# Altium schematic sheet 05_fmu_mcu2.SchDoc(05_fmu_mcu2)
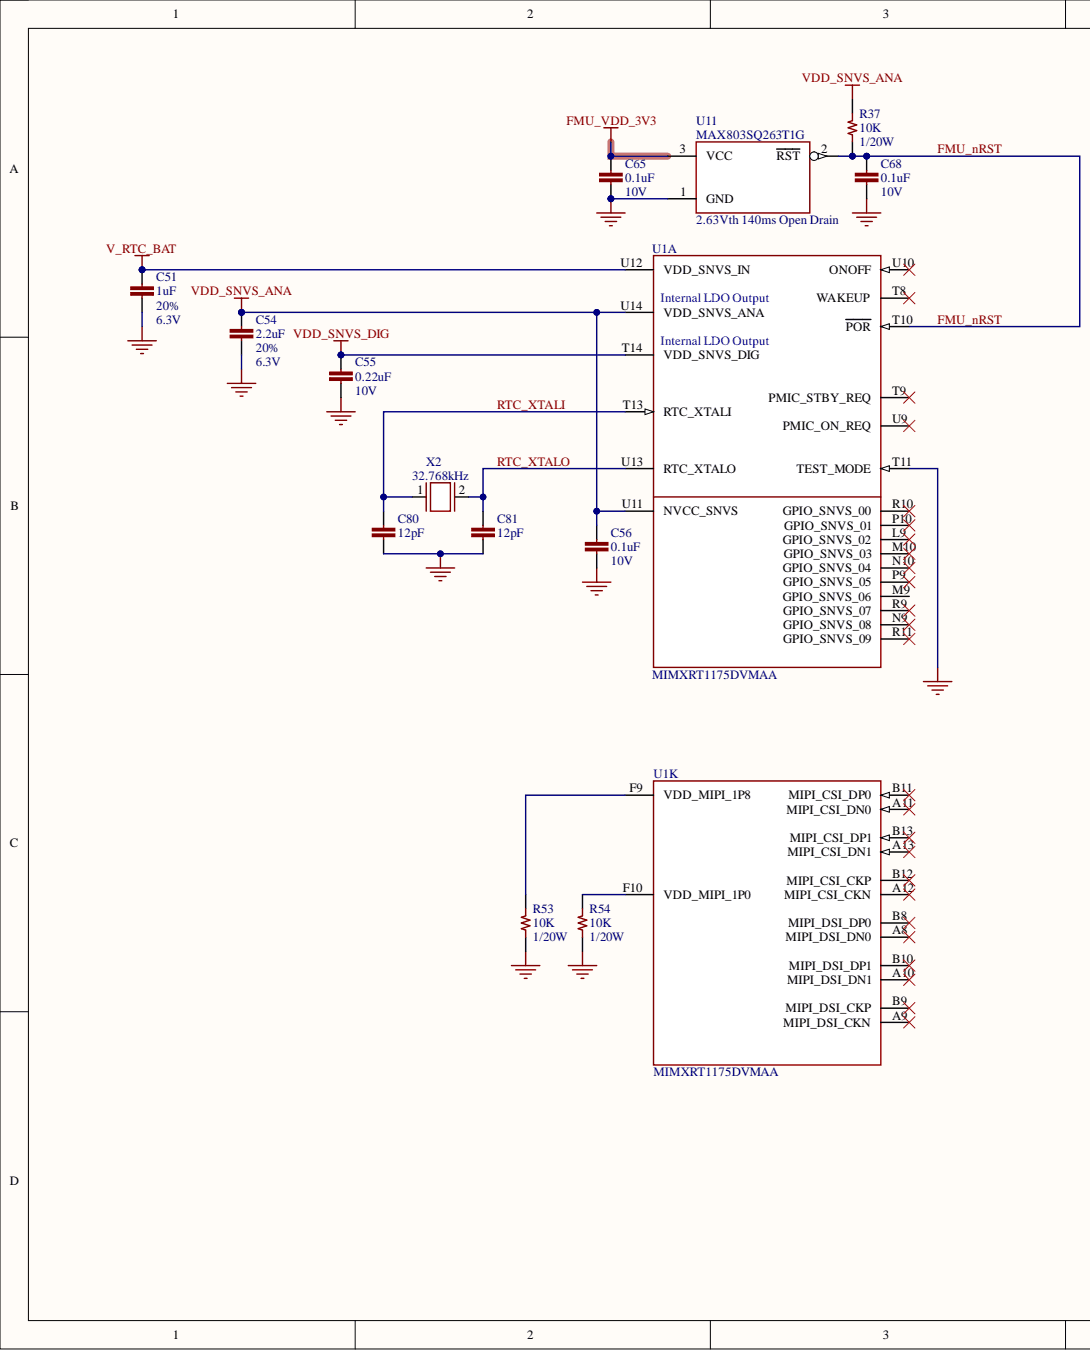
[diagram p0: 05_fmu_mcu2.SchDoc, left]
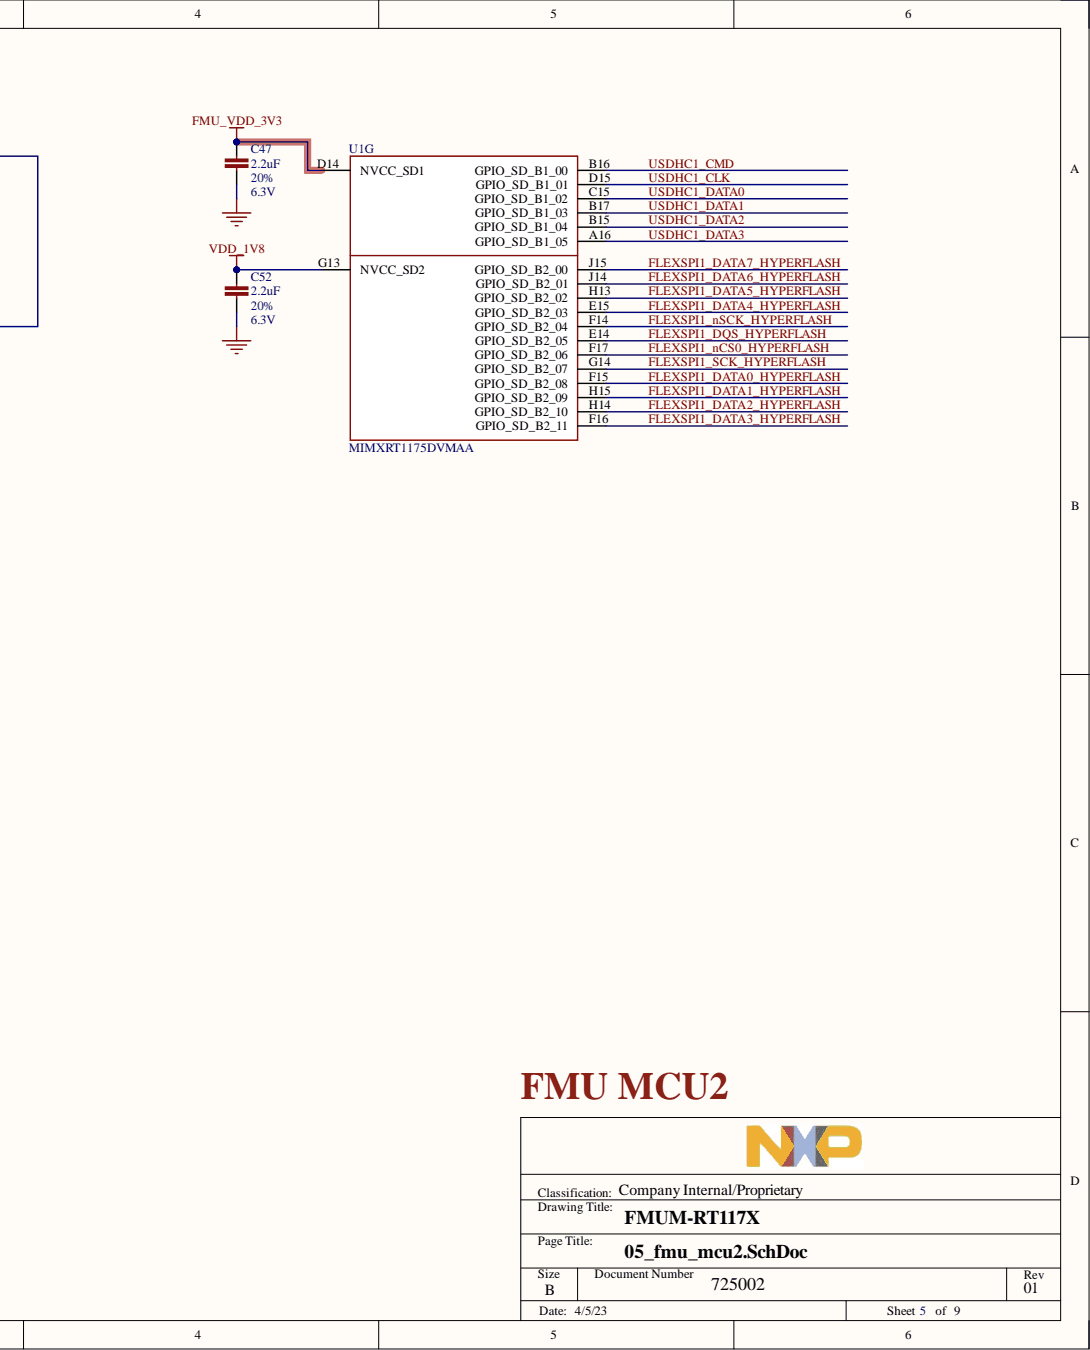
[diagram p1: 05_fmu_mcu2.SchDoc, right]

## Parts
C47  value 2.2uF  Tolerance: 20%  Voltage - Rated: 6.3V  [CAPC60X30X33L15N]  (hidden comment: CAP CER 2.2uF 20% 10V X5R 0201)
C51  value 1uF  Tolerance: 20%  Voltage - Rated: 6.3V  [CAPC60X30X33L15N]  (hidden comment: CAP CER 1uF 20% 10V X5R 0201)
C52  value 2.2uF  Tolerance: 20%  Voltage - Rated: 6.3V  [CAPC60X30X33L15N]  (hidden comment: CAP CER 2.2uF 20% 10V X5R 0201)
C54  value 2.2uF  Tolerance: 20%  Voltage - Rated: 6.3V  [CAPC60X30X33L15N]  (hidden comment: CAP CER 2.2uF 20% 10V X5R 0201)
C55  value 0.22uF  Voltage - Rated: 10V  [CAPC60X30X33L15N]  (hidden comment: CAP CER 0.22uF 10% 10V X5R 0201)
C56  value 0.1uF  Voltage - Rated: 10V  [CAPC60X30X33L15N]  (hidden comment: CAP CER 0.1uF 20% 10V X5R 0201)
C65  value 0.1uF  Voltage - Rated: 10V  [CAPC60X30X33L15N]  (hidden comment: CAP CER 0.1uF 20% 10V X5R 0201)
C68  value 0.1uF  Voltage - Rated: 10V  [CAPC60X30X33L15N]  (hidden comment: CAP CER 0.1uF 20% 10V X5R 0201)
C80  value 12pF  [CAPC60X30X33L15N]  (hidden comment: CAP CER 12PF 1% 50V COG/NPO 0201)
C81  value 12pF  [CAPC60X30X33L15N]  (hidden comment: CAP CER 12PF 1% 50V COG/NPO 0201)
R37  value 10K  Power: 1/20W  [RESC60X30X33L15N]  (hidden comment: RES SMD 10K OHM 1% 1/20W 0201)
R53  value 10K  Power: 1/20W  [RESC60X30X33L15N]  (hidden comment: RES SMD 10K OHM 1% 1/20W 0201)
R54  value 10K  Power: 1/20W  [RESC60X30X33L15N]  (hidden comment: RES SMD 10K OHM 1% 1/20W 0201)
U1  Manufacturer Part Number: MIMXRT1175DVMAA  [BGA289CP80_17X17_1400X1400X152B43N]  (hidden comment: IC MCU 32BIT EXT MEM 800MHz 289MAPBGA)  (parts A,G,K)
U11  ID: 2.63Vth 140ms Open Drain  Manufacturer Part Number: MAX803SQ263T1G  [SOT23-3P65_220X100L38X35]  (hidden comment: IC Supervisor Open Drain 1-Channel SC70-3)
X2  Frequency: 32.768kHz  [XTALDFN2_200X120X60L50X100N]  (hidden comment: CRYSTAL 32.7680KHZ 10PPM 12.5PF SMD)

## Nets
FMU_VDD_3V3: C47.1 C65.1 U1.D14(NVCC_SD1) U11.3
GND: C47.2 C51.2 C52.2 C54.2 C55.2 C56.2 C65.2 C68.2 C80.2 C81.2 R53.2 R54.2 U1.T11(TEST_MODE) U11.1
V_RTC_BAT: C51.1 U1.U12(VDD_SNVS_IN)
VDD_1V8: C52.1 U1.G13(NVCC_SD2)
VDD_SNVS_ANA: C54.1 C56.1 R37.1 U1.U11(NVCC_SNVS) U1.U14(VDD_SNVS_ANA)
VDD_SNVS_DIG: C55.1 U1.T14(VDD_SNVS_DIG)
FLEXSPI1_DATA0_HYPERFLASH: U1.F15(GPIO_SD_B2_08)
FLEXSPI1_DATA1_HYPERFLASH: U1.H15(GPIO_SD_B2_09)
FLEXSPI1_DATA2_HYPERFLASH: U1.H14(GPIO_SD_B2_10)
FLEXSPI1_DATA3_HYPERFLASH: U1.F16(GPIO_SD_B2_11)
FLEXSPI1_DATA4_HYPERFLASH: U1.E15(GPIO_SD_B2_03)
FLEXSPI1_DATA5_HYPERFLASH: U1.H13(GPIO_SD_B2_02)
FLEXSPI1_DATA6_HYPERFLASH: U1.J14(GPIO_SD_B2_01)
FLEXSPI1_DATA7_HYPERFLASH: U1.J15(GPIO_SD_B2_00)
FLEXSPI1_DQS_HYPERFLASH: U1.E14(GPIO_SD_B2_05)
FLEXSPI1_nCS0_HYPERFLASH: U1.F17(GPIO_SD_B2_06)
FLEXSPI1_nSCK_HYPERFLASH: U1.F14(GPIO_SD_B2_04)
FLEXSPI1_SCK_HYPERFLASH: U1.G14(GPIO_SD_B2_07)
FMU_nRST: C68.1 R37.2 U1.T10(POR) U11.2
RTC_XTALI: C80.1 U1.T13(RTC_XTALI) X2.1
RTC_XTALO: C81.1 U1.U13(RTC_XTALO) X2.2
USDHC1_CLK: U1.D15(GPIO_SD_B1_01)
USDHC1_CMD: U1.B16(GPIO_SD_B1_00)
USDHC1_DATA0: U1.C15(GPIO_SD_B1_02)
USDHC1_DATA1: U1.B17(GPIO_SD_B1_03)
USDHC1_DATA2: U1.B15(GPIO_SD_B1_04)
USDHC1_DATA3: U1.A16(GPIO_SD_B1_05)
NetR53_1: R53.1 U1.F9(VDD_MIPI_1P8)
NetR54_1: R54.1 U1.F10(VDD_MIPI_1P0)

Not connected: U1 A8(MIPI_DSI_DN0) A9(MIPI_DSI_CKN) A10(MIPI_DSI_DN1) A11(MIPI_CSI_DN0) A12(MIPI_CSI_CKN) A13(MIPI_CSI_DN1) B8(MIPI_DSI_DP0) B9(MIPI_DSI_CKP) B10(MIPI_DSI_DP1) B11(MIPI_CSI_DP0) B12(MIPI_CSI_CKP) B13(MIPI_CSI_DP1) L9(GPIO_SNVS_02) M9(GPIO_SNVS_06) M10(GPIO_SNVS_03) N9(GPIO_SNVS_08) N10(GPIO_SNVS_04) P9(GPIO_SNVS_05) P10(GPIO_SNVS_01) R9(GPIO_SNVS_07) R10(GPIO_SNVS_00) R11(GPIO_SNVS_09) T8(WAKEUP) T9(PMIC_STBY_REQ) U9(PMIC_ON_REQ) U10(ONOFF)

## Library data of the parts
C47 C52 C54 = CAP_2.2uF_6.3V_X5R_0201: Manufacturer: Murata Electronics; Manufacturer Part Number: GRM033R60J225ME47D; Category: Capacitors; Component Kind: Standard; ComponentLink1Description: https://www.digikey.com/en/products/detail/murata-electronics/GRM033R60J225ME47D/5877388; ComponentLink1URL: https://www.digikey.com/en/products/detail/murata-electronics/GRM033R60J225ME47D/5877388; Description: CAP CER 2.2uF 20% 10V X5R 0201; Operating Temperature: -55°C ~ 85°C; Packaging: Cut Tape (CT); Part Status: Active; Pricing 1: 1=0.18 (USD); Stock 1: 9156088; Supplier 1: Digi-Key; Supplier Part Number 1: 490-13225-1-ND; Library Name: NscDG_Integrated_Library.SchLib
C51 = CAP_1uF_6.3V_X5R_0201: Manufacturer: YAGEO; Manufacturer Part Number: CC0201MPX5R5BB105; Category: Capacitors; Component Kind: Standard; ComponentLink1Description: https://www.digikey.com/en/products/detail/yageo/CC0201MPX5R5BB105/11611316; ComponentLink1URL: https://www.digikey.com/en/products/detail/yageo/CC0201MPX5R5BB105/11611316; Description: CAP CER 1uF 20% 10V X5R 0201; Operating Temperature: -55°C ~ 85°C; Packaging: Cut Tape (CT); Part Status: Active; Pricing 1: 1=0.10 (USD); Stock 1: 20-2927; Supplier 1: Digi-Key; Supplier Part Number 1: 13-CC0201MPX5R5BB105CT-ND; Library Name: NscDG_Integrated_Library.SchLib
C55 = CAP_0.22uF_10V_X5R_0201: Manufacturer: Murata Electronics; Manufacturer Part Number: GRM033R61A224KE90D; Category: Capacitors; Component Kind: Standard; ComponentLink1Description: https://www.digikey.com/en/products/detail/murata-electronics/GRM033R61A224KE90D/5027496; ComponentLink1URL: https://www.digikey.com/en/products/detail/murata-electronics/GRM033R61A224KE90D/5027496; Description: CAP CER 0.22uF 10% 10V X5R 0201; Operating Temperature: -55°C ~ 85°C; Packaging: Cut Tape (CT); Part Status: Active; Pricing 1: 1=0.10 (USD); Stock 1: 4690076; Supplier 1: Digi-Key; Supplier Part Number 1: 490-10411-1-ND; Tolerance: 10%; Library Name: NscDG_Integrated_Library.SchLib
C56 C65 C68 = CAP_0.1uF_10V_X5R_0201: Manufacturer: Murata Electronics; Manufacturer Part Number: GRM033R61A104ME15D; Category: Capacitors; Component Kind: Standard; ComponentLink1Description: https://www.digikey.com/en/products/detail/murata-electronics/GRM033R61A104ME15D/2175191; ComponentLink1URL: https://www.digikey.com/en/products/detail/murata-electronics/GRM033R61A104ME15D/2175191; Description: CAP CER 0.1uF 20% 10V X5R 0201; Operating Temperature: -55°C ~ 85°C; Packaging: Cut Tape (CT); Part Status: Active; Pricing 1: 1=0.10 (USD); Stock 1: 12909013; Supplier 1: Digi-Key; Supplier Part Number 1: 490-5405-1-ND; Tolerance: 20%; Library Name: NscDG_Integrated_Library.SchLib
C80 C81 = CAP_12PF_50V_COG_NPO_0201: Manufacturer: Murata Electronics; Manufacturer Part Number: GRM0335C1H120FA01D; Category: Capacitors; Component Kind: Standard; ComponentLink1Description: https://www.digikey.com/en/products/detail/murata-electronics/GRM0335C1H120FA01D/11618590; ComponentLink1URL: https://www.digikey.com/en/products/detail/murata-electronics/GRM0335C1H120FA01D/11618590; Description: CAP CER 12PF 1% 50V COG/NPO 0201; Operating Temperature: -55°C ~ 125°C; Packaging: Cut Tape (CT); Part Status: Active; Pricing 1: 1=0.10 (USD); Stock 1: 380249; Supplier 1: Digi-Key; Supplier Part Number 1: 490-GRM0335C1H120FA01DCT-ND; Tolerance: 1%; Voltage - Rated: 50V; Library Name: NscDG_Integrated_Library.SchLib
R37 R53 R54 = RES_10K_1%_1/20W_0201: Manufacturer: Yageo; Manufacturer Part Number: AC0201FR-0710KL; Category: Resistors; Component Kind: Standard; ComponentLink1Description: https://www.digikey.com/en/products/detail/yageo/AC0201FR-0710KL/5894228; ComponentLink1URL: https://www.digikey.com/en/products/detail/yageo/AC0201FR-0710KL/5894228; Description: RES SMD 10K OHM 1% 1/20W 0201; Operating Temperature: -55°C ~ 125°C; Packaging: Cut Tape (CT); Part Status: Active; Pricing 1: 1=0.1, 10=0.014, 100=0.0056, 1000=0.00253, 2500=0.0022, 5000=0.00181 (USD); Stock 1: 739618; Supplier 1: Digi-Key; Supplier Part Number 1: YAG3413CT-ND; Tolerance: ±1%; Library Name: NscDG_Integrated_Library.SchLib
U1 = IC_MIMXRT1175DVMAA_289MAPBGA: Manufacturer: NXP USA; Category: Integrated Circuits (ICs); Component Kind: Standard; ComponentLink1Description: https://www.digikey.com/en/products/detail/nxp-usa-inc/MIMXRT1062DVL6B/13635843?s=N4IgTCBcDaILIEk4A0BKAVAjABgGxgBEA1AGVwCEQBdAXyA; ComponentLink1URL: https://www.digikey.com/en/products/detail/nxp-usa-inc/MIMXRT1175DVMAA/13536094?s=N4IgTCBcDaIJIFkAaAlAKgRgwdgKwBEA1BAQRJAF0BfIA; Description: IC MCU 32BIT EXT MEM 800MHz 289MAPBGA; Operating Temperature: 0C to 95C; Packaging: Tray; Part Status: Active; Pricing 1: 1=17.93 (USD); Stock 1: 0; Supplier 1: DigiKey; Supplier Part Number 1: 568-MIMXRT1175DVMAA-ND; Library Name: NscDG_Integrated_Library.SchLib
U11 = IC_MAX803SQ263T1G_SC70-3: Manufacturer: onsemi; Category: Integrated Circuits (ICs); Component Kind: Standard; ComponentLink1Description: https://www.digikey.com/en/products/detail/onsemi/MAX803SQ263T1G/1792210?s=N4IgTCBcDaILIEEAaAOADAZgMoEUwDYMAVARgHEQBdAXyA; ComponentLink1URL: https://www.digikey.com/en/products/detail/onsemi/MAX803SQ263T1G/1792210?s=N4IgTCBcDaILIEEAaAOADAZgMoEUwDYMAVARgHEQBdAXyA; Description: IC Supervisor Open Drain 1-Channel SC70-3; Operating Temperature: -40°C ~ 105°C; Packaging: Cut Tape (CT); Part Status: Active; Pricing 1: 1=0.61 (USD); Stock 1: 0; Supplier 1: Digi-Key; Supplier Part Number 1: MAX803SQ263T1GOSCT-ND; Library Name: NscDG_Integrated_Library.SchLib
X2 = XTAL_32KHZ_10PPM_12PF_2-SMD: Manufacturer: ECS Inc.; Manufacturer Part Number: ECS-.327-12.5-12-C-TR; Category: Crystals, Oscillators, Resonators; Component Kind: Standard; ComponentLink1Description: https://www.digikey.com/en/products/detail/citizen-finedevice-co-ltd/CM315D32768EZFT/3641382?s=N4IgTCBcDaIMIFkDMBGArAESWA7ANgA4BRALQDEAVEAXQF8g; ComponentLink1URL: https://www.digikey.com/en/products/detail/ecs-inc/ECS-327-12-5-12-C-TR/6821608; Description: CRYSTAL 32.7680KHZ 10PPM 12.5PF SMD; Frequency Stability: -; Frequency Tolerance: ±10ppm; Load Capacitance: 12.5pF; Operating Temperature: -40°C ~ 85°C; Packaging: Cut Tape (CT); Part Status: Active; Pricing 1: 1=0.89 (USD); Stock 1: 31069; Supplier 1: Digi-Key; Supplier Part Number 1: XC2288CT-ND; Library Name: NscDG_Integrated_Library.SchLib
